# Altium schematic sheet Sch-QWA59_USB_Hub.SchDoc
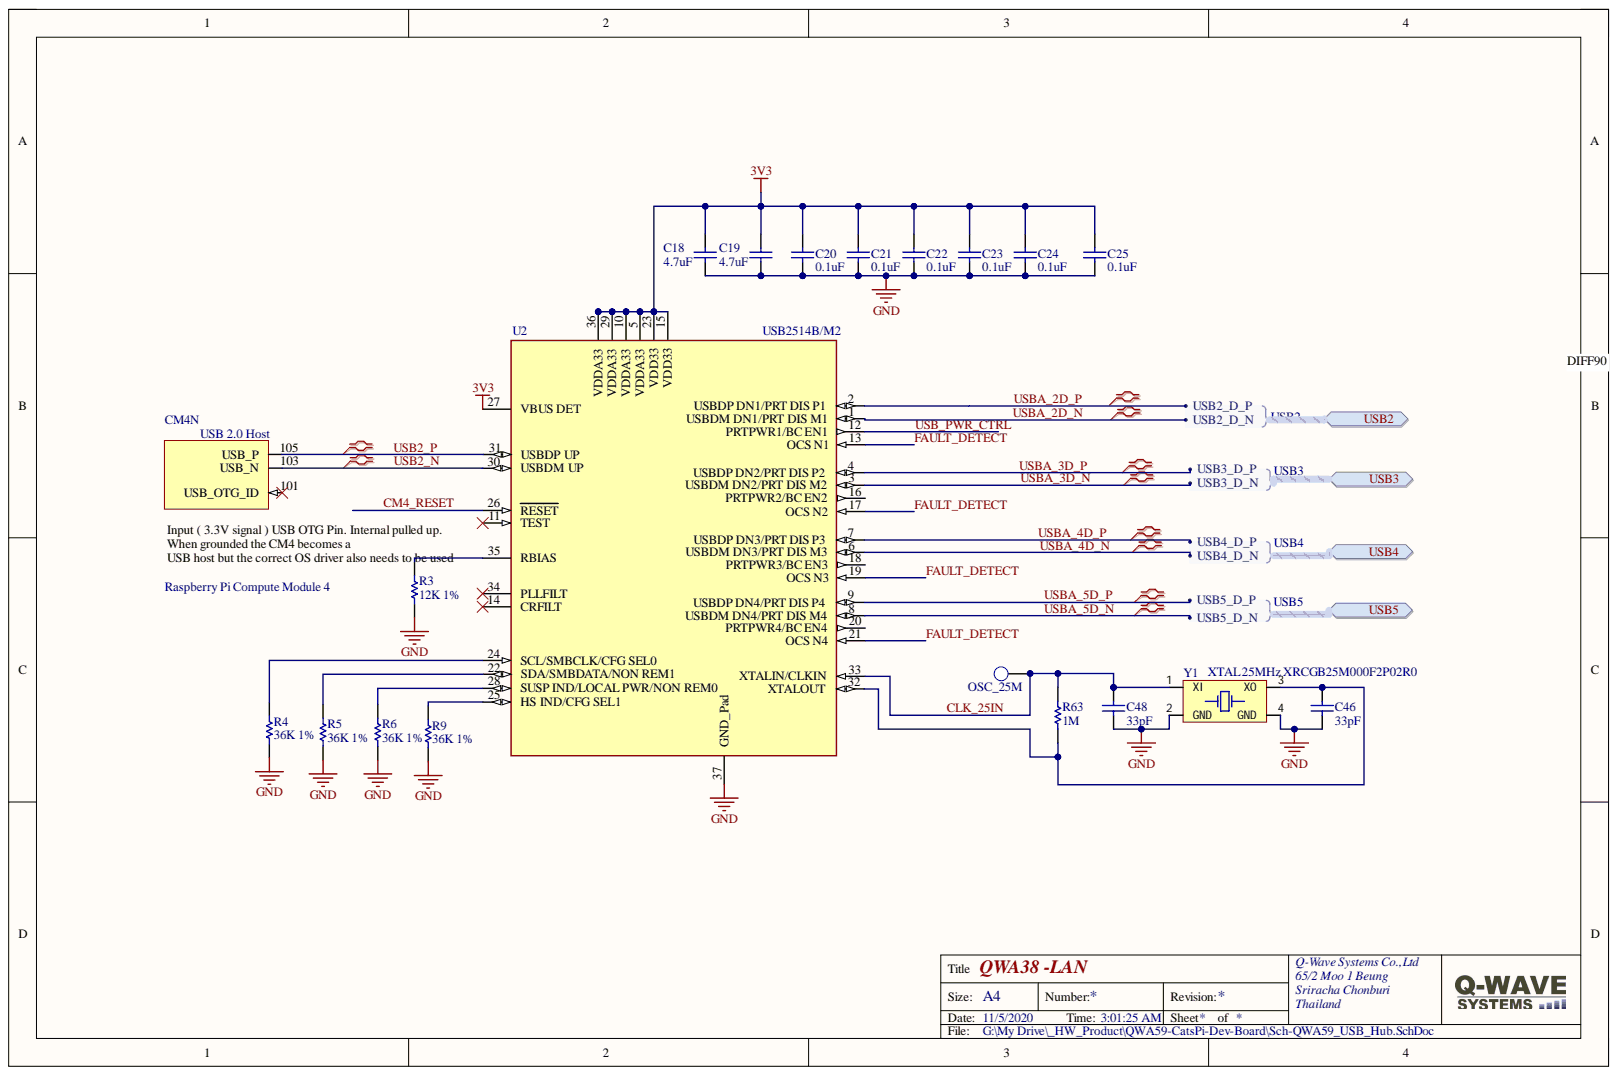
[diagram p0: Sch-QWA59_USB_Hub.SchDoc]

## Parts
C18  4.7uF  [6-0805_N]
C19  4.7uF  [6-0805_N]
C20  0.1uF  [CAP_0603]
C21  0.1uF  [CAP_0603]
C22  0.1uF  [CAP_0603]
C23  0.1uF  [CAP_0603]
C24  0.1uF  [CAP_0603]
C25  0.1uF  [CAP_0603]
C46  33pF  [CAP_0603]
C48  33pF  [CAP_0603]
CM4  Raspberry Pi Compute Module 4  [CM4-F40C-100DS-0.4v_1.5mm_0mm]  (part N)
OSC_25M  OSC_25M  [TEST_POINT]
R3  12K 1%  [RES_0603]
R4  36K 1%  [RES_0603]
R5  36K 1%  [RES_0603]
R6  36K 1%  [RES_0603]
R9  36K 1%  [RES_0603]
R63  1M  [RES_0603]
U2  USB2514B/M2  [USB2514BM2_SQFN]
Y1  XTAL 25MHz XRCGB25M000F2P02R0  [XT_2016_4]

## Ports
USB2, USB2.USB2_D_N, USB2.USB2_D_P, USB3, USB3.USB3_D_N, USB3.USB3_D_P, USB4, USB4.USB4_D_N, USB4.USB4_D_P, USB5, USB5.USB5_D_N, USB5.USB5_D_P

## Nets
3V3: C18.2 C19.2 C20.2 C21.2 C22.2 C23.2 C24.2 C25.2 U2.5(VDDA33) U2.10(VDDA33) U2.15(VDD33) U2.23(VDD33) U2.27(VBUS_DET) U2.29(VDDA33) U2.36(VDDA33)
GND: C18.1 C19.1 C20.1 C21.1 C22.1 C23.1 C24.1 C25.1 C46.2 C48.1 R3.2 R4.2 R5.2 R6.2 R9.2 U2.37(GND_Pad) Y1.2(GND) Y1.4(GND)
CLK_25IN: C48.2 OSC_25M.1 R63.2 U2.33(XTALIN/CLKIN) Y1.1(XI)
CM4_RESET: U2.26(RESET)
FAULT_DETECT: U2.13(OCS_N1) U2.17(OCS_N2) U2.19(OCS_N3) U2.21(OCS_N4)
USB2_N: CM4.103 U2.30(USBDM_UP)
USB2_P: CM4.105 U2.31(USBDP_UP)
USB_PWR_CTRL: U2.12(PRTPWR1/BC_EN1)
USBA_2D_N: U2.1(USBDM_DN1/PRT_DIS_M1) ; port USB2
USBA_2D_P: U2.2(USBDP_DN1/PRT_DIS_P1) ; port USB2
USBA_3D_N: U2.3(USBDM_DN2/PRT_DIS_M2) ; port USB3
USBA_3D_P: U2.4(USBDP_DN2/PRT_DIS_P2) ; port USB3
USBA_4D_N: U2.6(USBDM_DN3/PRT_DIS_M3) ; port USB4
USBA_4D_P: U2.7(USBDP_DN3/PRT_DIS_P3) ; port USB4
USBA_5D_N: U2.8(USBDM_DN4/PRT_DIS_M4) ; port USB5
USBA_5D_P: U2.9(USBDP_DN4/PRT_DIS_P4) ; port USB5
NetC46_1: C46.1 R63.1 U2.32(XTALOUT) Y1.3(XO)
NetR3_1: R3.1 U2.35(RBIAS)
NetR4_1: R4.1 U2.24(SCL/SMBCLK/CFG_SEL0)
NetR5_1: R5.1 U2.22(SDA/SMBDATA/NON_REM1)
NetR6_1: R6.1 U2.28
NetR9_1: R9.1 U2.25(HS_IND/CFG_SEL1)

Not connected: CM4 101; U2 11(TEST) 14(CRFILT) 16(PRTPWR2/BC_EN2) 18(PRTPWR3/BC_EN3) 20(PRTPWR4/BC_EN4) 34(PLLFILT)

## Library data of the parts
C18 C19 = Cap: Capacitor; Part Number: GRM188R61A475KE15D; ClassName: uSD_slot; Component Kind: Standard; LatestRevisionDate: 17-Jul-2002; LatestRevisionNote: Re-released for DXP Platform.; Library Name: Miscellaneous Devices.IntLib; PackageDescription: Radial Cap, Thru-Hole; 2 Leads; 0.3 in Pin Spacing; PackageReference: RAD-0.3; Published: 8-Jun-2000; Signal Integrity: Cap; Simulation: CAP; Supplier: LCSC; Value: 4.7uF; Publisher: Altium Limited
C20-C25 = Cap Semi: 0.1µF ±5% 50V Ceramic Capacitor X7R 0603; MFG: KEMET; MFG P/N: C0603C104J5RACTU; Component Kind: Standard; Library Name: Miscellaneous Devices.IntLib; Signal Integrity: Cap Semi; Simulation: CAP
C46 C48 = Cap Semi: 33pF ±5% 50V Ceramic Capacitor C0G, NP0 0603; MFG: KEMET; Component Kind: Standard; Library Name: Miscellaneous Devices.IntLib; Signal Integrity: Cap Semi; Simulation: CAP
CM4 = CM4: Raspberry Pi Compute Module 4 by Amornthep Phunsin; Component Kind: Standard; Library Name: CM4.SchLib
OSC_25M = TEST_POINT: Test Point; Component Kind: Standard; Library Name: Misc.SCHLIB
R3-R6 R9 R63 = Res Semi: Resistor 0603; Component Kind: Standard; Library Name: Miscellaneous Devices.IntLib; Signal Integrity: Res Semi; Simulation: RES
U2 = USB2514B/M2: IC HUB CTLR 4PORT USB 2.0 HS 36S; Manufacturer: Microchip; Interface: I2C,USB,SMBus; Max Supply Voltage: 3.6V; Min Supply Voltage: 3V; Nominal Supply Current: 35-70mA; Number Of Ports: 4; Standoff Height: 0mm; Usb Standard: USB 2.0; Ambient Temperature Range High: +85°C; Ambient Temperature Range Low: 0°C; Automotive: No; Category: IC; Component Kind: Standard; ComponentLink2Description: Datasheet; ComponentLink2URL: http://ww1.microchip.com/downloads/en/DeviceDoc/00001692C.pdf; Device Class L1: Integrated Circuits (ICs); Device Class L2: Interface ICs; Device Class L3: USB Interface ICs; Digikey Description: IC HUB CTLR 4PORT USB 2.0 HS 36S; Height: 1mm; Ipc Land Pattern Name: QFN50P600X600X90-36; Lead Free: Yes; Library Name: QWA59.SchLib; Manufacturer Part Number: USB2514B/M2; Mouser Description: Low Speed/Full Speed/High Speed 7 Port Hub Controller USB 2.0 Tray 36-Pin SQFN EP; Octopart: https://octopart.com/usb2514b%2Fm2-microchip-57152181; Package: 36SQFN-3706-6x6B; RoHS: TRUE; Temperature: 0°C to +85°C; Temperature Range High: +85°C; Temperature Range Low: 0°C
Y1 = XTAL_2016_4P: Crystal Oscillator; Value: ?MHz; Component Kind: Standard; Library Name: SCH_Oscillator.SchLib; Type: XTAL2016
